# Altium schematic sheet lumina.SchDoc(lumina)
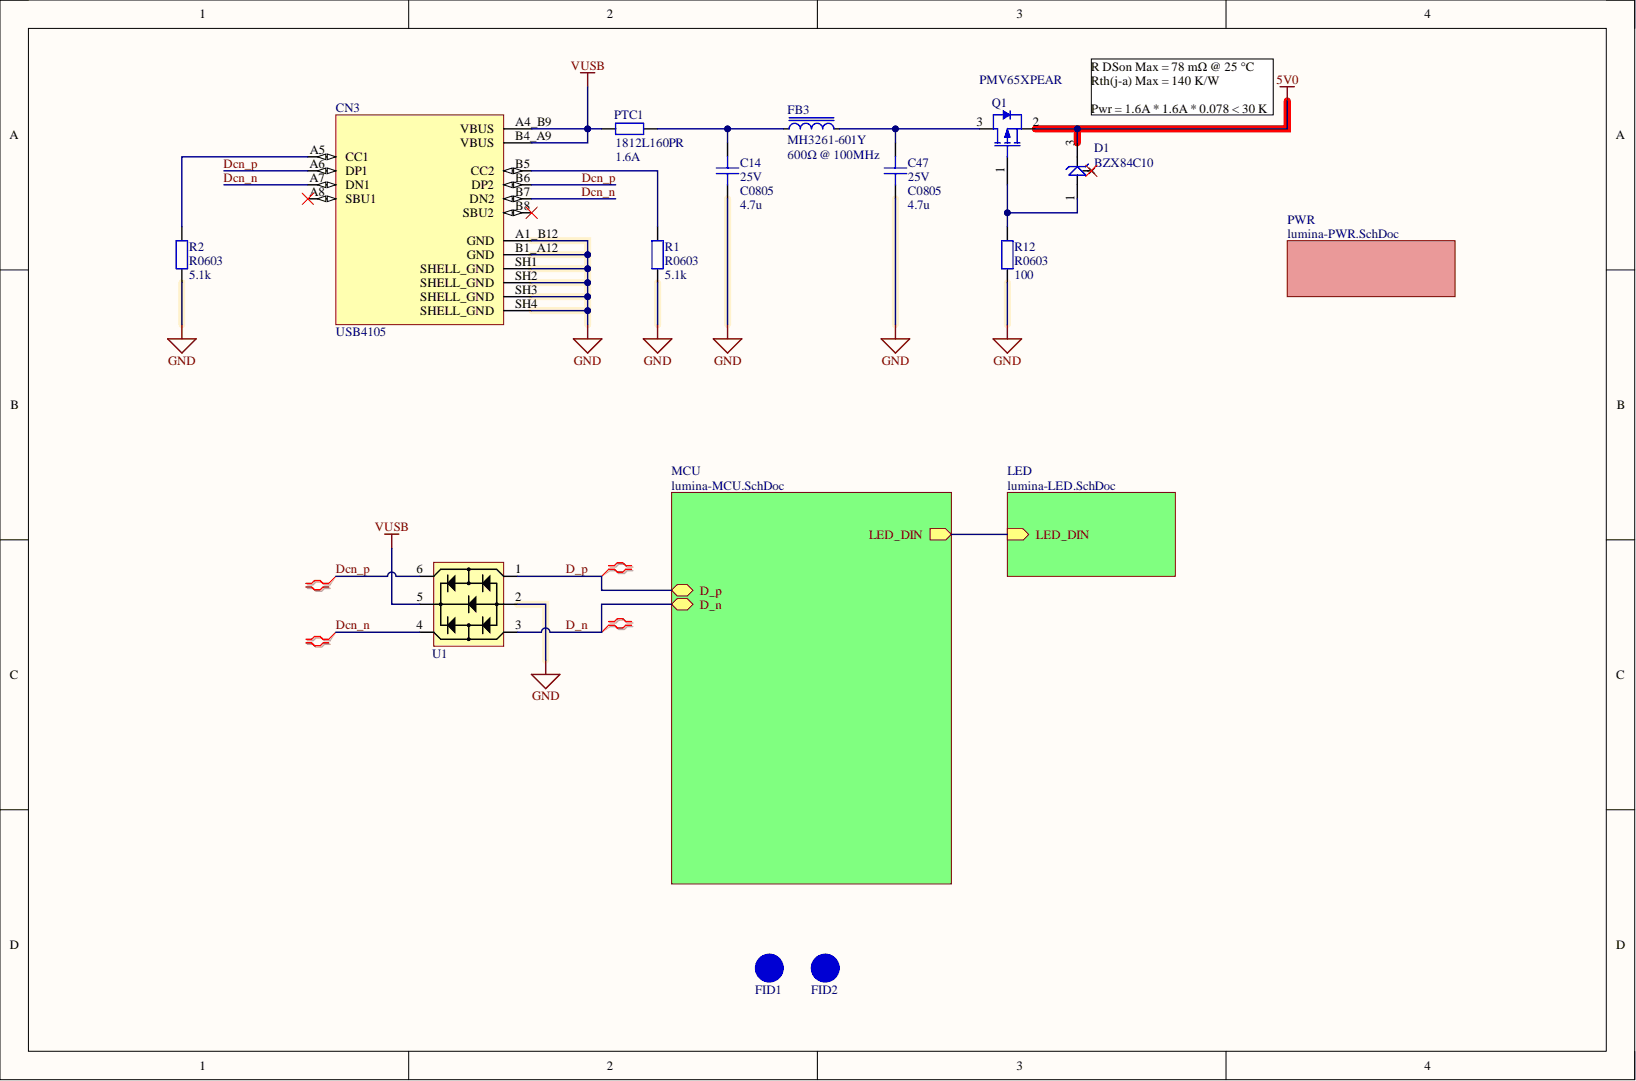
[diagram p0: lumina.SchDoc]

## Parts
C14  C0805  value 4.7u  Voltage: 25V  [CAP_0805_N]
C47  C0805  value 4.7u  Voltage: 25V  [CAP_0805_N]
CN3  USB4105  [GCT_USB4105-GF-A]
D1  BZX84C10  [SOT-23-3]
FB3  MH3261-601Y  value 600Ω @ 100MHz  [IND_1206_N]
FID1  FID_1MM  [FID_1MM]  (value not drawn)
FID2  FID_1MM  [FID_1MM]  (value not drawn)
PTC1  1812L160PR  value 1.6A  [RES_1812_N]
Q1  PMV65XPEAR  [SOT-23-3]
R1  R0603  value 5.1k  [RES_0603_N]
R2  R0603  value 5.1k  [RES_0603_N]
R12  R0603  value 100  [RES_0603_N]
U1  USBLC6-2  [SOT-23-6]  (value not drawn)

## Nets
5V0: D1.3 Q1.2
GND: C14.1 C47.1 CN3.A1_B12(GND) CN3.B1_A12(GND) CN3.SH1(SHELL_GND) CN3.SH2(SHELL_GND) CN3.SH3(SHELL_GND) CN3.SH4(SHELL_GND) R1.1 R2.1 R12.2 U1.2
VUSB: CN3.A4_B9(VBUS) CN3.B4_A9(VBUS) PTC1.1 U1.5
D_n: U1.3
D_p: U1.1
Dcn_n: CN3.A7(DN1) CN3.B7(DN2) U1.4
Dcn_p: CN3.A6(DP1) CN3.B6(DP2) U1.6
NetC14_2: C14.2 FB3.1 PTC1.2
NetC47_2: C47.2 FB3.2 Q1.3
NetCN3_A5: CN3.A5(CC1) R2.2
NetCN3_A8: CN3.A8(SBU1)
NetCN3_B5: CN3.B5(CC2) R1.2
NetCN3_B8: CN3.B8(SBU2)
NetD1_1: D1.1 Q1.1 R12.1
NetD1_2: D1.2
LED_DIN:

## Library data of the parts
C14 C47 = C0805: SMD Capacitor 2.0mm x 1.3mm  0.08in x 0.05in; Manufacturer 1: Samsung Electro-Mechanics; Manufacturer PN 1: CL21A475KAQNNNE; Component Kind: Standard; Library Name: Capacitors SMD.IntLib; Package: C0805; Supplier 1: LCSC; Supplier PN 1: C98190
CN3 = USB4105: Type C USB 2.0 Connector; Manufacturer 1: GCT; Component Kind: Standard; Library Name: Connectors.IntLib; Manufacturer PN 1: USB4105; Supplier 1: LCSC; Supplier PN 1: C136423
D1 = BZX84C2V7: SURFACE MOUNT ZENER DIODE; Manufacturer 1: ST(Semtech); Component Kind: Standard; Library Name: Diode SMD.IntLib; Manufacturer PN 1: BZX84C10; Supplier 1: LCSC; Supplier PN 1: C235751
FB3 = FB_1206: Ferrite bead 1206; Manufacturer 1: Bourns; Value: 600Ω @ 100MHz; Component Kind: Standard; Library Name: Inductors SMD.IntLib; Manufacturer PN 1: MH3261-601Y; Supplier 1: LCSC; Supplier PN 1: C840591
FID1 FID2 = FID_1MM: Fiducial Point; Component Kind: Net Tie; Supplier 1: LCSC; Library Name: Contacts.IntLib
PTC1 = R1812_IEC: SMD Resistor 4.6mm x 3.0mm  0.18in x 0.12in; Manufacturer 1: UNI-ROYAL; Manufacturer PN 1: 1812L150ZR; Power rating: 1/2 W; Component Kind: Standard; Library Name: Resistors SMD.IntLib; Package: R1812; Supplier 1: LCSC; Supplier PN 1: C207073
Q1 = PMOS_SOT-23-3: Generic PMOS SOT-23-3; Manufacturer 1: Nexperia; Component Kind: Standard; Library Name: Transistors SMD.IntLib; Manufacturer PN 1: PMV65XPEAR; Supplier 1: LCSC; Supplier PN 1: C2889116
R1 R2 = R0603_IEC: SMD Resistor 1.5mm x 0.08mm  0.06in x 0.03in; Manufacturer 1: UNI-ROYAL; Manufacturer PN 1: 0603WAF5101T5E; Power rating: 1/10 W; Component Kind: Standard; Library Name: Resistors SMD.IntLib; Package: R0603; Supplier 1: LCSC; Supplier PN 1: C23186
R12 = R0603_IEC: SMD Resistor 1.5mm x 0.08mm  0.06in x 0.03in; Manufacturer 1: UNI-ROYAL; Manufacturer PN 1: 0603WAF1000T5E; Power rating: 1/10 W; Component Kind: Standard; Library Name: Resistors SMD.IntLib; Package: R0603; Supplier 1: LCSC; Supplier PN 1: C22775
U1 = USBLC6-2: ESD Suppressors / TVS Diodes; Manufacturer 1: STMicroelectronics; Component Kind: Standard; Library Name: Diode SMD.IntLib; Manufacturer PN 1: USBLC6-2; Supplier 1: LCSC; Supplier PN 1: C7519
